# Altium schematic sheet ESP32.SchDoc(ESP32)
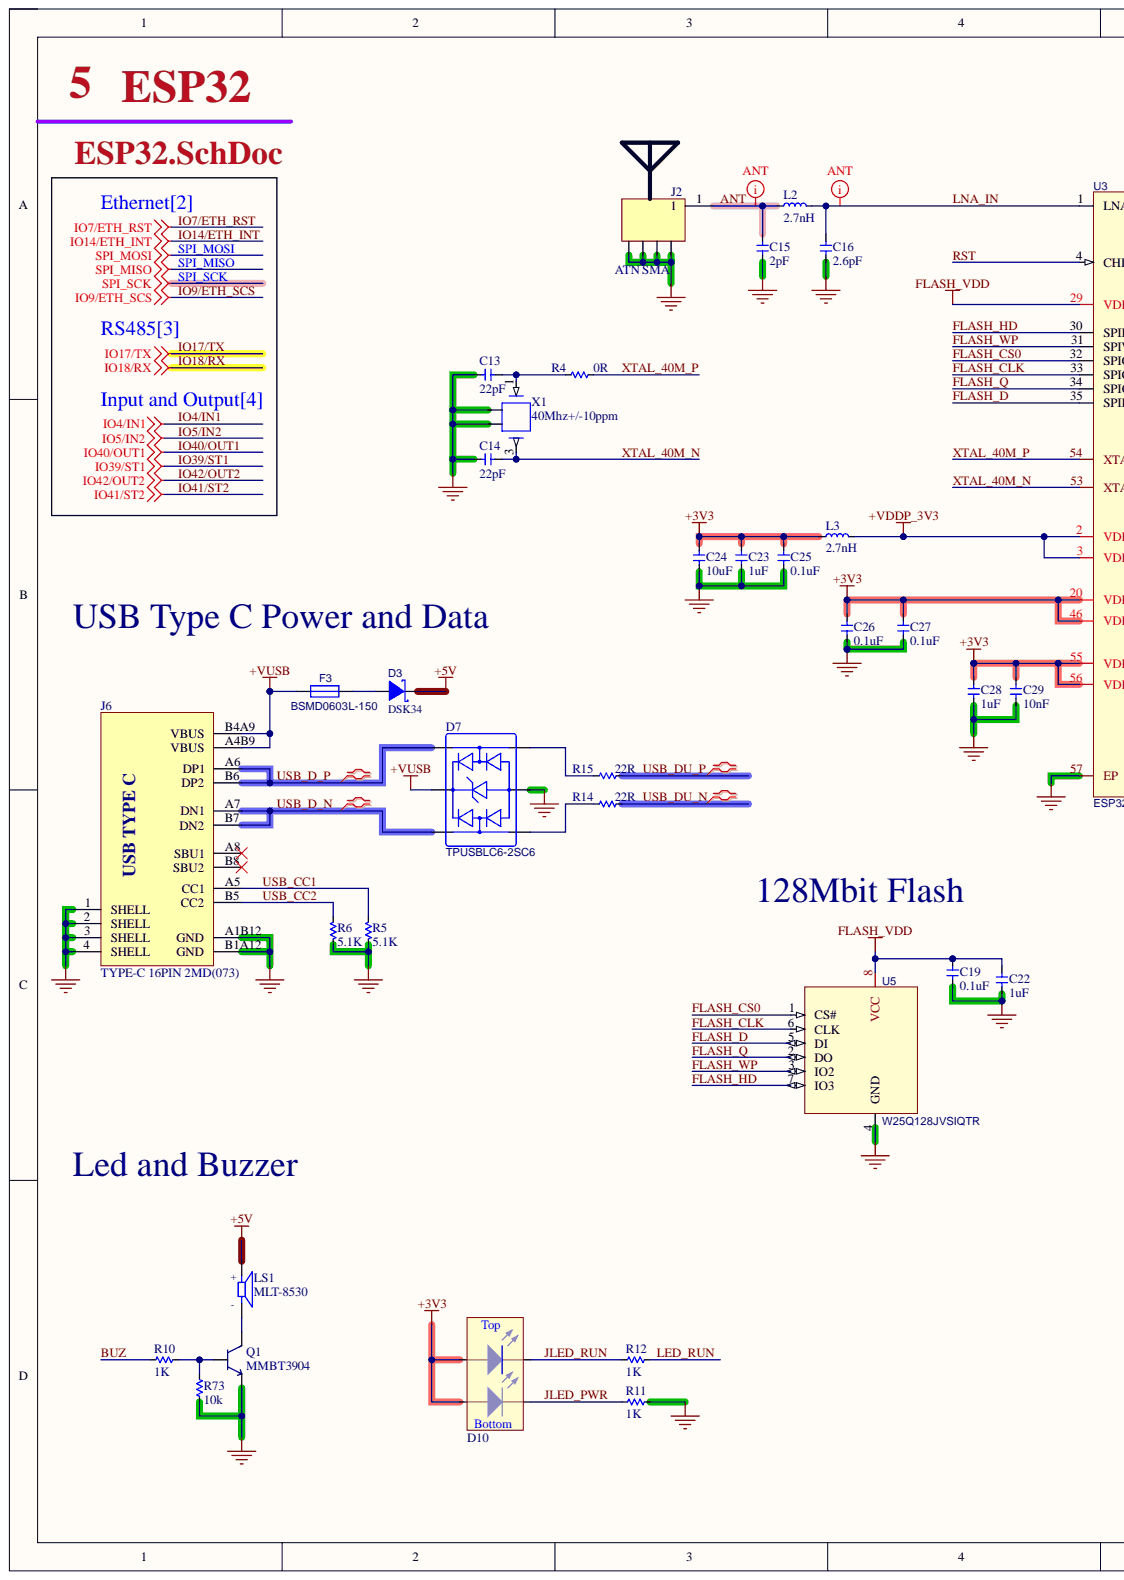
[diagram p0: ESP32.SchDoc, left]
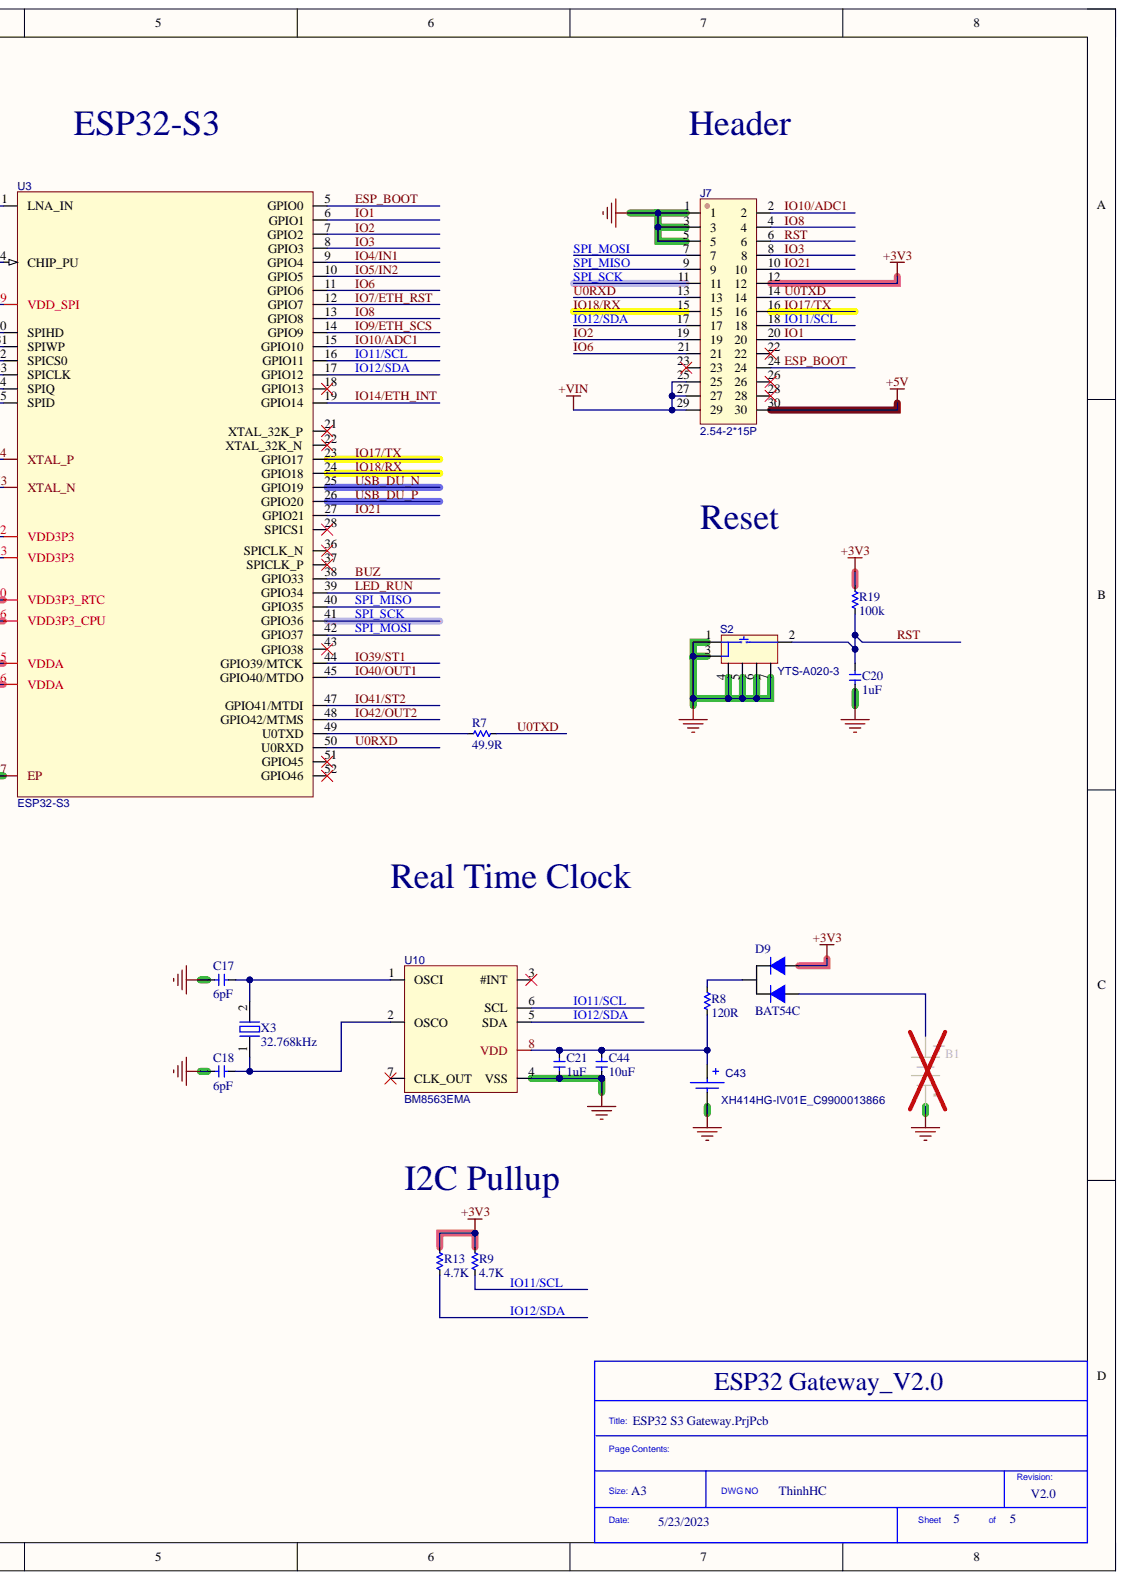
[diagram p1: ESP32.SchDoc, right]

## Parts
B1  CR1220-2ZX  [BAT_CR1220_SMD]  (value not drawn)
C13  22pF  [C_0402]
C14  22pF  [C_0402]
C15  2pF  [C_0402]
C16  2.6pF  [C_0402]
C17  6pF  [C_0402]
C18  6pF  [C_0402]
C19  0.1uF  [C_0402]
C20  1uF  [C_0402]
C21  1uF  [C_0402]
C22  1uF  [C_0402]
C23  1uF  [C_0402]
C24  10uF  [C_0402]
C25  0.1uF  [C_0402]
C26  0.1uF  [C_0402]
C27  0.1uF  [C_0402]
C28  1uF  [C_0402]
C29  10nF  [C_0402]
C43  XH414HG-IV01E_C9900013866  [CAP-TH_XH414HG]
C44  10uF  [C_0402]
D3  DSK34  [SOD-123_L2.8-W1.8-LS3.7-RD]
D7  TPUSBLC6-2SC6  [SOT-23-6_L2.9-W1.6-P0.95-LS2.8-BR]
D9  BAT54C  [SOT-23-3_L2.9-W1.6-P1.90-LS2.8-BR]
D10  2LED_3MM  [2LED_3MM]  (value not drawn)
F3  BSMD0603L-150  [F0603]
J2  ATN SMA  [ANTEN_SMA]
J6  TYPE-C 16PIN 2MD(073)  [USB-C-SMD_TYPE-C16PIN]
J7  2.54-2*15P  [HDR-TH_30P-P2.54-V-F-R2-C15-S2.54]
L2  2.7nH  [L_0402]
L3  2.7nH  [L_0402]
LS1  MLT-8530  [Buzzer SMD]
Q1  MMBT3904  [SOT-23-3]
R4  0R  [R_0402]
R5  5.1K  [R_0402]
R6  5.1K  [R_0402]
R7  49.9R  [R_0402]
R8  120R  [R_0603]
R9  4.7K  [R_0402]
R10  1K  [R_0402]
R11  1K  [R_0402]
R12  1K  [R_0402]
R13  4.7K  [R_0402]
R14  22R  [R_0402]
R15  22R  [R_0402]
R19  100k  [R_0402]
R73  10k  [R_0402]
S2  YTS-A020-3  [SW-SMD_YIYUAN_YTS-A020-3]
U3  ESP32-S3  [QFN-56_L7.0-W7.0-P0.40-TL-EP4.0]
U5  W25Q128JVSIQTR  [SOIC-8_L5.3-W5.3-P1.27-LS8.0-BL]
U10  BM8563EMA  [MSOP-8_L3.0-W3.0-P0.65-LS5.0-BL]
X1  40Mhz+/-10ppm  [SMD-3225_4P]
X3  32.768kHz  [CRYSTAL-SMD_L3.2-W1.5]

## Nets
+3V3: C23.2 C24.1 C25.2 C26.2 C27.2 C28.2 C29.2 D9.2 D10.1 D10.3 J7.12 L3.1 R9.1 R13.1 R19.2 U3.20(VDD3P3_RTC) U3.46(VDD3P3_CPU) U3.55(VDDA) U3.56(VDDA)
+5V: D3.1 J7.30 LS1.1
+VDDP_3V3: L3.2 U3.2(VDD3P3) U3.3(VDD3P3)
+VIN: J7.25 J7.27 J7.29
+VUSB: D7.5 F3.1 J6.A4B9(VBUS) J6.B4A9(VBUS)
FLASH_VDD: C19.2 C22.2 U3.29(VDD_SPI) U5.8(VCC)
GND: B1.2 C13.1 C14.1 C15.1 C16.1 C17.1 C18.1 C19.1 C20.1 C21.1 C22.1 C23.1 C24.2 C25.1 C26.1 C27.1 C28.1 C29.1 C43.2 C44.1 D7.2 J2.2 J2.3 J2.4 J2.5 J6.1(SHELL) J6.2(SHELL) J6.3(SHELL) J6.4(SHELL) J6.A1B12(GND) J6.B1A12(GND) J7.1 J7.3 J7.5 Q1.2 R5.1 R6.1 R11.2 R73.1 S2.1 S2.3 S2.4 S2.5 S2.6 S2.7 U3.57(EP) U5.4(GND) U10.4(VSS) X1.2 X1.4
ANT: C15.2 J2.1 L2.1
BUZ: R10.1 U3.38(GPIO33)
ESP_BOOT: J7.24 U3.5(GPIO0)
FLASH_CLK: U3.33(SPICLK) U5.6(CLK)
FLASH_CS0: U3.32(SPICS0) U5.1(CS#)
FLASH_D: U3.35(SPID) U5.5(DI)
FLASH_HD: U3.30(SPIHD) U5.7(IO3)
FLASH_Q: U3.34(SPIQ) U5.2(DO)
FLASH_WP: U3.31(SPIWP) U5.3(IO2)
IO1: J7.20 U3.6(GPIO1)
IO2: J7.19 U3.7(GPIO2)
IO3: J7.8 U3.8(GPIO3)
IO4/IN1: U3.9(GPIO4)
IO5/IN2: U3.10(GPIO5)
IO6: J7.21 U3.11(GPIO6)
IO7/ETH_RST: U3.12(GPIO7)
IO8: J7.4 U3.13(GPIO8)
IO9/ETH_SCS: U3.14(GPIO9)
IO10/ADC1: J7.2 U3.15(GPIO10)
IO11/SCL: J7.18 R9.2 U3.16(GPIO11) U10.6(SCL)
IO12/SDA: J7.17 R13.2 U3.17(GPIO12) U10.5(SDA)
IO14/ETH_INT: U3.19(GPIO14)
IO17/TX: J7.16 U3.23(GPIO17)
IO18/RX: J7.15 U3.24(GPIO18)
IO21: J7.10 U3.27(GPIO21)
IO39/ST1: U3.44(GPIO39/MTCK)
IO40/OUT1: U3.45(GPIO40/MTDO)
IO41/ST2: U3.47(GPIO41/MTDI)
IO42/OUT2: U3.48(GPIO42/MTMS)
JLED_PWR: D10.2 R11.1
JLED_RUN: D10.4 R12.1
LED_RUN: R12.2 U3.39(GPIO34)
LNA_IN: C16.2 L2.2 U3.1(LNA_IN)
RST: C20.2 J7.6 R19.1 S2.2 U3.4(CHIP_PU)
SPI_MISO: J7.9 U3.40(GPIO35)
SPI_MOSI: J7.7 U3.42(GPIO37)
SPI_SCK: J7.11 U3.41(GPIO36)
U0RXD: J7.13 U3.50(U0RXD)
U0TXD: J7.14 R7.2
USB_CC1: J6.A5(CC1) R5.2
USB_CC2: J6.B5(CC2) R6.2
USB_D_N: D7.4 J6.A7(DN1) J6.B7(DN2)
USB_D_P: D7.6 J6.A6(DP1) J6.B6(DP2)
USB_DU_N: R14.2 U3.25(GPIO19)
USB_DU_P: R15.2 U3.26(GPIO20)
XTAL_40M_N: C14.2 U3.53(XTAL_N) X1.3
XTAL_40M_P: R4.2 U3.54(XTAL_P)
NetB1_1: B1.1 D9.1
NetC13_2: C13.2 R4.1 X1.1
NetC17_2: C17.2 U10.1(OSCI) X3.2
NetC18_2: C18.2 U10.2(OSCO) X3.1
NetC21_2: C21.2 C43.1 C44.2 R8.1 U10.8(VDD)
NetD3_2: D3.2 F3.2
NetD7_1: D7.1 R15.1
NetD7_3: D7.3 R14.1
NetD9_3: D9.3 R8.2
NetLS1_2: LS1.2 Q1.3
NetQ1_1: Q1.1 R10.2 R73.2
NetR7_1: R7.1 U3.49(U0TXD)

Not connected: J6 A8(SBU1) B8(SBU2); J7 22 23 26 28; U3 18(GPIO13) 21(XTAL_32K_P) 22(XTAL_32K_N) 28(SPICS1) 36(SPICLK_N) 37(SPICLK_P) 43(GPIO38) 51(GPIO45) 52(GPIO46); U10 3(#INT) 7(CLK_OUT)

## Library data of the parts
B1 = CR1220-2ZX_2: SMD Battery Holders, Clips & Contacts RoHS 1220 batteries; Manufacturer: Q&J; Assembly Type: SMT Assembly; Component Kind: Standard; ComponentLink1Description: https://datasheet.ciiva.com/16220/m60-2p2-16220480.pdf?src-supplier=Future+Electronics; ComponentLink1URL: https://datasheet.ciiva.com/16220/m60-2p2-16220480.pdf?src-supplier=Future+Electronics; ComponentLink2Description: https://datasheet.ciiva.com/18491/1056-18491289.pdf?src-supplier=Digi-Key; ComponentLink2URL: https://datasheet.ciiva.com/18491/1056-18491289.pdf?src-supplier=Digi-Key; ComponentLink3Description: https://datasheet.ciiva.com/26923/getdatasheetpartid-755844-26923850.pdf?src-supplier=Verical; ComponentLink3URL: https://datasheet.ciiva.com/26923/getdatasheetpartid-755844-26923850.pdf?src-supplier=Verical; LCSC Part Number: C969906; Library Name: ESP32 S3 Gateway.SchLib; Manufacturer Part Number: CR1220-2ZX; Package: SMD
C13 C14 = 0402CG220J500NT: 50V 22pF C0G ±5% 0402 Multilayer Ceramic Capacitors MLCC - SMD/SMT ROHS; Manufacturer: FH (Guangdong Fenghua Advanced Tech); Assembly Type: SMT Assembly; Component Kind: Standard; ComponentLink1Description: Digikey Link; ComponentLink1URL: https://www.digikey.com/en/products/detail/yageo/CC0603KRX7R9BB104/2103082?s=N4IgTCBcDaIMwEYEFoFwCzuWZA5AIiALoC%2BQA; ComponentLink2Description: LCSC Link; ComponentLink2URL: https://jlcpcb.com/parts/componentSearch?searchTxt=10k%200603; LCSC Part Number: C1555; Library Name: ESP32 Gateway_V2.0.SchLib; Manufacturer Part Number: 0402CG220J500NT; Package: 0402
C15 = 0402CG2R0B500NT: 50V 2pF 0402 Multilayer Ceramic Capacitors MLCC - SMD/SMT ROHS; Manufacturer: FH (Guangdong Fenghua Advanced Tech); Assembly Type: SMT Assembly; Component Kind: Standard; ComponentLink1Description: Digikey Link; ComponentLink1URL: https://www.digikey.com/en/products/detail/yageo/CC0603KRX7R9BB104/2103082?s=N4IgTCBcDaIMwEYEFoFwCzuWZA5AIiALoC%2BQA; ComponentLink2Description: LCSC Link; ComponentLink2URL: https://jlcpcb.com/parts/componentSearch?searchTxt=10k%200603; LCSC Part Number: C285089; Library Name: ESP32 Gateway_V2.0.SchLib; Manufacturer Part Number: 0402CG2R0B500NT; Package: 0402
C16 = GCM1555C1H2R6CA16D: 50V 2.6pF 0402 Multilayer Ceramic Capacitors MLCC - SMD/SMT ROHS; Manufacturer: Murata Electronics; Assembly Type: SMT Assembly; Component Kind: Standard; ComponentLink1Description: Digikey Link; ComponentLink1URL: https://www.digikey.com/en/products/detail/yageo/CC0603KRX7R9BB104/2103082?s=N4IgTCBcDaIMwEYEFoFwCzuWZA5AIiALoC%2BQA; ComponentLink2Description: LCSC Link; ComponentLink2URL: https://jlcpcb.com/parts/componentSearch?searchTxt=10k%200603; LCSC Part Number: C2190109; Library Name: ESP32 Gateway_V2.0.SchLib; Manufacturer Part Number: GCM1555C1H2R6CA16D; Package: 0402
C17 C18 = 0402CG6R0C500NT: 50V 6pF X7R ±10% 0402 Multilayer Ceramic Capacitors MLCC - SMD/SMT ROHS; Manufacturer: FH (Guangdong Fenghua Advanced Tech); Assembly Type: SMT Assembly; Component Kind: Standard; ComponentLink1Description: Digikey Link; ComponentLink1URL: https://www.digikey.com/en/products/detail/yageo/CC0603KRX7R9BB104/2103082?s=N4IgTCBcDaIMwEYEFoFwCzuWZA5AIiALoC%2BQA; ComponentLink2Description: LCSC Link; ComponentLink2URL: https://jlcpcb.com/parts/componentSearch?searchTxt=10k%200603; LCSC Part Number: C1575; Library Name: ESP32 S3 Gateway.SchLib; Manufacturer Part Number: 0402CG6R0C500NT; Package: 0402
C19 C25-C27 = CL05B104KB54PNC: 50V 100nF X7R ±10% 0402 Multilayer Ceramic Capacitors MLCC - SMD/SMT ROHS; Manufacturer: Samsung Electro-Mechanics; Assembly Type: SMT Assembly; Component Kind: Standard; ComponentLink1Description: Digikey Link; ComponentLink1URL: https://www.digikey.com/en/products/detail/yageo/CC0603KRX7R9BB104/2103082?s=N4IgTCBcDaIMwEYEFoFwCzuWZA5AIiALoC%2BQA; ComponentLink2Description: LCSC Link; ComponentLink2URL: https://jlcpcb.com/parts/componentSearch?searchTxt=10k%200603; LCSC Part Number: C307331; Library Name: ESP32 Gateway_V2.0.SchLib; Manufacturer Part Number: CL05B104KB54PNC; Package: 0402
C20-C23 C28 = CL05A105KA5NQNC: 25V 1uF X5R ±10% 0402 Multilayer Ceramic Capacitors MLCC - SMD/SMT ROHS; Manufacturer: Samsung Electro-Mechanics; Assembly Type: SMT Assembly; Component Kind: Standard; ComponentLink1Description: Digikey Link; ComponentLink1URL: https://www.digikey.com/en/products/detail/yageo/CC0603KRX7R9BB104/2103082?s=N4IgTCBcDaIMwEYEFoFwCzuWZA5AIiALoC%2BQA; ComponentLink2Description: LCSC Link; ComponentLink2URL: https://jlcpcb.com/parts/componentSearch?searchTxt=10k%200603; LCSC Part Number: C52923; Manufacturer Part Number: CL05A105KA5NQNC; Package: 0402
  C20 C22 C23 C28: Library Name: ESP32 Gateway_V2.0.SchLib
  C21: Library Name: ESP32 S3 Gateway.SchLib
C24 C44 = CL05A106MQ5NUNC: 6.3V 10uF X5R ±20% 0402 Multilayer Ceramic Capacitors MLCC - SMD/SMT ROHS; Manufacturer: Samsung Electro-Mechanics; Assembly Type: SMT Assembly; Component Kind: Standard; ComponentLink1Description: Digikey Link; ComponentLink1URL: https://www.digikey.com/en/products/detail/yageo/CC0603KRX7R9BB104/2103082?s=N4IgTCBcDaIMwEYEFoFwCzuWZA5AIiALoC%2BQA; ComponentLink2Description: LCSC Link; ComponentLink2URL: https://jlcpcb.com/parts/componentSearch?searchTxt=10k%200603; LCSC Part Number: C15525; Library Name: ESP32 S3 Gateway.SchLib; Manufacturer Part Number: CL05A106MQ5NUNC; Package: 0402
C29 = CL05B103KB5NNNC: 50V 10nF X7R ±10% 0402 Multilayer Ceramic Capacitors MLCC - SMD/SMT ROHS; Manufacturer: Samsung Electro-Mechanics; Assembly Type: SMT Assembly; Component Kind: Standard; ComponentLink1Description: Digikey Link; ComponentLink1URL: https://www.digikey.com/en/products/detail/yageo/CC0603KRX7R9BB104/2103082?s=N4IgTCBcDaIMwEYEFoFwCzuWZA5AIiALoC%2BQA; ComponentLink2Description: LCSC Link; ComponentLink2URL: https://jlcpcb.com/parts/componentSearch?searchTxt=10k%200603; LCSC Part Number: C15195; Library Name: ESP32 Gateway_V2.0.SchLib; Manufacturer Part Number: CL05B103KB5NNNC; Package: 0402
C43 = XH414HG-IV01E_C9900013866: Cap Supercap 80000uF 3.3V (4.8 X 4.8 X 1.85mm) SMD Stacked Coin; Assembly Type: SMT Assembly; Component Kind: Standard; LCSC Part Number: C9900013866; Library Name: ESP32 S3 Gateway.SchLib; Manufacturer: N/A; Manufacturer Part Number: XH414HG-IV01E; Package: SMD
D3 = DSK34: DSK34 40V 550mV@3A 3A SOD-123 Schottky Barrier Diodes (SBD) ROHS Copy; Manufacturer: MDD（Microdiode Electronics); Assembly Type: SMT Assembly; Component Kind: Standard; LCSC Part Number: C41029; Library Name: ESP32 S3 Gateway.SchLib; Manufacturer Part Number: DSK34; Package: SOD-123
D7 = ESD_TPUSBLC6-2SC6: ESD ROHS SOT23-6 DIODES; Manufacturer: TECH PUBLIC; Assembly Type: SMT Assembly; Component Kind: Standard; LCSC Part Number: C558442; Library Name: ESP32 S3 Gateway.SchLib; Manufacturer Part Number: TPUSBLC6-2SC6; Package: SOT-23-6
D9 = BAT54CLT1G: SCHOTTKY BARRIER DIODES (SBD) SOT-23(SOT-23-3) ROHS; Manufacturer: ON Semicon; Assembly Type: SMT Assembly; Component Kind: Standard; LCSC Part Number: C14308; Library Name: ESP32 S3 Gateway.SchLib; Manufacturer Part Number: BAT54CLT1G; Package: SOT-23-3
D10 = 2LED3MM: LED DUAL RED/GREEN DIFFUSED T/H; Manufacturer: Lumex; Manufacturer Part Number: SSF-LXH240IGD; Assembly Type: Hand Soldering; Component Kind: Standard; ComponentLink1Description: https://datasheet.ciiva.com/16387/lumex20ssf-lxh240igd-16387412.pdf?src-supplier=Future+Electronics; ComponentLink1URL: https://datasheet.ciiva.com/16387/lumex20ssf-lxh240igd-16387412.pdf?src-supplier=Future+Electronics; ComponentLink2Description: https://datasheet.ciiva.com/4673/ssf-lxh240igd-59870-4673513.pdf?src-supplier=Mouser; ComponentLink2URL: https://datasheet.ciiva.com/4673/ssf-lxh240igd-59870-4673513.pdf?src-supplier=Mouser; ComponentLink3Description: https://datasheet.ciiva.com/14605/70127278-14605558.pdf?src-supplier=Allied+Electronics; ComponentLink3URL: https://datasheet.ciiva.com/14605/70127278-14605558.pdf?src-supplier=Allied+Electronics; ComponentLink4Description: https://datasheet.ciiva.com/16840/70127280-16840571.pdf?src-supplier=Allied+Electronics; ComponentLink4URL: https://datasheet.ciiva.com/16840/70127280-16840571.pdf?src-supplier=Allied+Electronics; ComponentLink5Description: https://datasheet.ciiva.com/1535/ssf-lxh240igd-1535964.pdf?src-supplier=Element14; ComponentLink5URL: https://datasheet.ciiva.com/1535/ssf-lxh240igd-1535964.pdf?src-supplier=Element14; ComponentLink6Description: https://datasheet.ciiva.com/1644/ssf-lxh240igd-1644716.pdf?src-supplier=Farnell; ComponentLink6URL: https://datasheet.ciiva.com/1644/ssf-lxh240igd-1644716.pdf?src-supplier=Farnell; ComponentLink7Description: https://datasheet.ciiva.com/1536/ssf-lxh240igd-1536046.pdf?src-supplier=Element14; ComponentLink7URL: https://datasheet.ciiva.com/1536/ssf-lxh240igd-1536046.pdf?src-supplier=Element14; ComponentLink8Description: https://datasheet.ciiva.com/1746/ssf-lxh240igd-1746846.pdf?src-supplier=Newark; ComponentLink8URL: https://datasheet.ciiva.com/1746/ssf-lxh240igd-1746846.pdf?src-supplier=Newark; ComponentLink9Description: http://datasheet.octopart.com/SSF-LXH240IGD-Lumex-datasheet-60839.pdf?src-supplier=Element14; ComponentLink9URL: http://datasheet.octopart.com/SSF-LXH240IGD-Lumex-datasheet-60839.pdf?src-supplier=Element14; ComponentLink10Description: http://datasheet.octopart.com/SSF-LXH240IGD-Lumex-datasheet-9743625.pdf?src-supplier=Allied+Electronics; ComponentLink10URL: http://datasheet.octopart.com/SSF-LXH240IGD-Lumex-datasheet-9743625.pdf?src-supplier=Allied+Electronics; LCSC Part Number: N/A; Library Name: ESP32 S3 Gateway.SchLib; Package: Through Hole
F3 = BSMD0603L-150: 6V 1.5A 50A 1W -40℃~+85℃ 3A 5mΩ 500ms 55mΩ 0603; Manufacturer: BHFUSE; Assembly Type: SMT Assembly; Component Kind: Standard; LCSC Part Number: C914094; Library Name: ESP32 S3 Gateway.SchLib; Manufacturer Part Number: BSMD0603L-150; Package: 0603
J2 = Antena_SMA: Connector; SMB Straight; Assembly Type: Hand Soldering; Component Kind: Standard; LCSC Part Number: N/A; Library Name: ESP32 Gateway_V2.0.SchLib; Manufacturer: N/A; Manufacturer Part Number: N/A; Package: Through Hole
J6 = TYPE-C 16PIN 2MD(073): 5A 1 Surface Mount 16 Female -25℃~+85℃ Type-C SMD USB Connectors ROHS; Manufacturer: SHOU HAN; Assembly Type: SMT Assembly; Component Kind: Standard; LCSC Part Number: C2765186; Library Name: ESP32 S3 Gateway.SchLib; Manufacturer Part Number: TYPE-C16PIN; Package: SMD
J7 = 2.54-2*15P: Straight,P=2.54mm  Female Headers ROHS; Manufacturer: BOOMELE(博穆精密); Assembly Type: SMT Assembly; Component Kind: Standard; LCSC Part Number: C5337168; Library Name: ESP32 S3 Gateway.SchLib; Manufacturer Part Number: 2.54-2*15P; Package: Straight,P=2.54mm
L2 L3 = CLH1005T-2N7S-S: 400mA 2.7nH 150mΩ 0402 Inductors (SMD) ROHS; Manufacturer: Chilisin Elec; Assembly Type: SMT Assembly; Component Kind: Standard; LCSC Part Number: C108255; Library Name: ESP32 Gateway_V2.0.SchLib; Manufacturer Part Number: CLH1005T-2N7S-S; Package: 0402
LS1 = MLT-8530: Externally Driven 80dB@5V,10cm Magnetic 3.6V 2700Hz SMD,8.5x8.5mm Buzzers ROHS; Manufacturer: Jiangsu Huaneng Elec; Assembly Type: Hand Soldering; Component Kind: Standard; LCSC Part Number: C94599; Library Name: ESP32 Gateway_V2.0.SchLib; Manufacturer Part Number: MLT-8530; Package: SMD,8.5x8.5mm
Q1 = MMBT3904: MMBT3904 TRANSISTORS (NPN/PNP) NPN 200MA 40V SOT-23(SOT-23-3) ROHS; Manufacturer: Changjiang Electronics Tech (CJ); Assembly Type: SMT Assembly; Component Kind: Standard; LCSC Part Number: C20526; Library Name: ESP32 S3 Gateway.SchLib; Manufacturer Part Number: MMBT3904; Package: SOT-23-3
R4 = 0402WGF0000TCE: 62.5mW Thick Film Resistors ±1% 0Ω 0402 Chip Resistor - Surface Mount ROHS; Manufacturer: UNI-ROYAL(Uniroyal Elec); Assembly Type: SMT Assembly; Component Kind: Standard; LCSC Part Number: C17168; Library Name: ESP32 Gateway_V2.0.SchLib; Manufacturer Part Number: 0402WGF0000TCE; Package: 0402
R5 R6 = 0402WGF5101TCE: 62.5mW Thick Film Resistors ±1% 5.1kΩ 0402 Chip Resistor - Surface Mount ROHS; Manufacturer: UNI-ROYAL(Uniroyal Elec); Assembly Type: SMT Assembly; Component Kind: Standard; LCSC Part Number: C25905; Library Name: ESP32 Gateway_V2.0.SchLib; Manufacturer Part Number: 0402WGF5101TCE; Package: 0402
R7 = 0402WGF499JTCE: 62.5mW Thick Film Resistors ±1% 49.9Ω 0402 Chip Resistor - Surface Mount ROHS; Manufacturer: UNI-ROYAL(Uniroyal Elec); Assembly Type: SMT Assembly; Component Kind: Standard; LCSC Part Number: C25120; Library Name: ESP32 S3 Gateway.SchLib; Manufacturer Part Number: 0402WGF499JTCE; Package: 0402
R8 = 0603WAF1200T5E: 100mW Thick Film Resistors 75V ±100ppm/℃ ±1% -55℃~+155℃ 120Ω 0603 Chip Resistor - Surface Mount ROHS; Manufacturer: UNI-ROYAL(Uniroyal Elec); Assembly Type: SMT Assembly; Component Kind: Standard; LCSC Part Number: C22787; Library Name: ESP32 S3 Gateway.SchLib; Manufacturer Part Number: 0603WAF1200T5E; Package: 0603
R9 R13 = 0402WGF4701TCE: 62.5mW Thick Film Resistors ±1% 4.7kΩ 0402 Chip Resistor - Surface Mount ROHS; Manufacturer: UNI-ROYAL(Uniroyal Elec); Assembly Type: SMT Assembly; Component Kind: Standard; LCSC Part Number: C25900; Library Name: ESP32 S3 Gateway.SchLib; Manufacturer Part Number: 0402WGF4701TCE; Package: 0402
R10-R12 = 0402WGF1001TCE: 62.5mW Thick Film Resistors ±1% 1kΩ 0402 Chip Resistor - Surface Mount ROHS; Manufacturer: UNI-ROYAL(Uniroyal Elec); Assembly Type: SMT Assembly; Component Kind: Standard; LCSC Part Number: C11702; Manufacturer Part Number: 0402WGF1001TCE; Package: 0402
  R10: Library Name: ESP32 Gateway_V2.0.SchLib
  R11 R12: Library Name: ESP32 S3 Gateway.SchLib
R14 R15 = 0402WGF220JTCE: 22R 22Ω  ±1% 0402 Chip Resistor - Surface Mount ROHS; Manufacturer: UNI-ROYAL(Uniroyal Elec); Assembly Type: SMT Assembly; Component Kind: Standard; LCSC Part Number: C25092; Library Name: ESP32 S3 Gateway.SchLib; Manufacturer Part Number: 0402WGF220JTCE; Package: 0402
R19 = 0402WGF1003TCE: 100kΩ  ±1% 0402 Chip Resistor - Surface Mount ROHS; Manufacturer: UNI-ROYAL(Uniroyal Elec); Assembly Type: SMT Assembly; Component Kind: Standard; LCSC Part Number: C25741; Library Name: ESP32 S3 Gateway.SchLib; Manufacturer Part Number: 0402WGF1003TCE; Package: 0402
R73 = 0402WGF1002TCE: 10kΩ 1% 0402 Chip Resistor - Surface Mount ROHS; Manufacturer: UNI-ROYAL(Uniroyal Elec); Assembly Type: SMT Assembly; Component Kind: Standard; LCSC Part Number: C25744; Library Name: ESP32 S3 Gateway.SchLib; Manufacturer Part Number: 0402WGF1002TCE; Package: 0402
S2 = YTS-A020-3: 50mA Surface Mount SPST 100MΩ 100000 Times 12V 250gf@±50gf SMD Tactile Switches ROHS; Manufacturer: YIYUAN(怡远); Assembly Type: SMT Assembly; Component Kind: Standard; LCSC Part Number: C2910682; Library Name: ESP32 S3 Gateway.SchLib; Manufacturer Part Number: YTS-A020-3; Package: SMD
U3 = ESP32-S3: ESP32-S3 QFN-56-EP(7x7)  RF Transceiver ICs ROHS; Manufacturer: ESPRESSIF(乐鑫); Assembly Type: SMT Assembly; Component Kind: Standard; LCSC Part Number: C2913192; Library Name: ESP32 S3 Gateway.SchLib; Manufacturer Part Number: ESP32-S3; Package: QFN-56-EP_7x7
U5 = W25Q128JVSIQTR: W25Q128JVSIQTR 128Mbit SOP-8-208mil NOR FLASH ROHS; Manufacturer: WINBOND; Assembly Type: SMT Assembly; Component Kind: Standard; LCSC Part Number: C97521; Library Name: ESP32 S3 Gateway.SchLib; Manufacturer Part Number: W25Q128JVSIQ; Package: SOP-8-208mil
U10 = BM8563EMA: MSOP-8  Real-time Clocks (RTC) ROHS; Manufacturer: GATEMODE(捷茂微); Assembly Type: SMT Assembly; Component Kind: Standard; LCSC Part Number: C269878; Library Name: ESP32 S3 Gateway.SchLib; Manufacturer Part Number: BM8563EMA; Package: MSOP-8
X1 = X322540MPB4SI: 40MHz 15pF ±10ppm ±30ppm SMD3225-4P Crystals ROHS; Manufacturer: Yangxing Tech; Assembly Type: SMT Assembly; Component Kind: Standard; LCSC Part Number: C9010; Library Name: ESP32 Gateway_V2.0.SchLib; Manufacturer Part Number: X322540MPB4SI; Package: SMD3225-4P
X3 = Q13FC13500005: 32.768kHz 12.5pF ±10ppm SMD3215-2P Crystals ROHS; Manufacturer: Seiko Epson; Assembly Type: SMT Assembly; Component Kind: Standard; ComponentLink1Description: Digikey Link; ComponentLink1URL: https://www.digikey.com/en/products/detail/yageo/CC0603KRX7R9BB104/2103082?s=N4IgTCBcDaIMwEYEFoFwCzuWZA5AIiALoC%2BQA; ComponentLink2Description: LCSC Link; ComponentLink2URL: https://jlcpcb.com/parts/componentSearch?searchTxt=10k%200603; LCSC Part Number: C841881; Library Name: ESP32 Gateway_V2.0.SchLib; Manufacturer Part Number: Q13FC13500005; Package: SMD3215-2P
